# Altium schematic sheet TOP.SchDoc
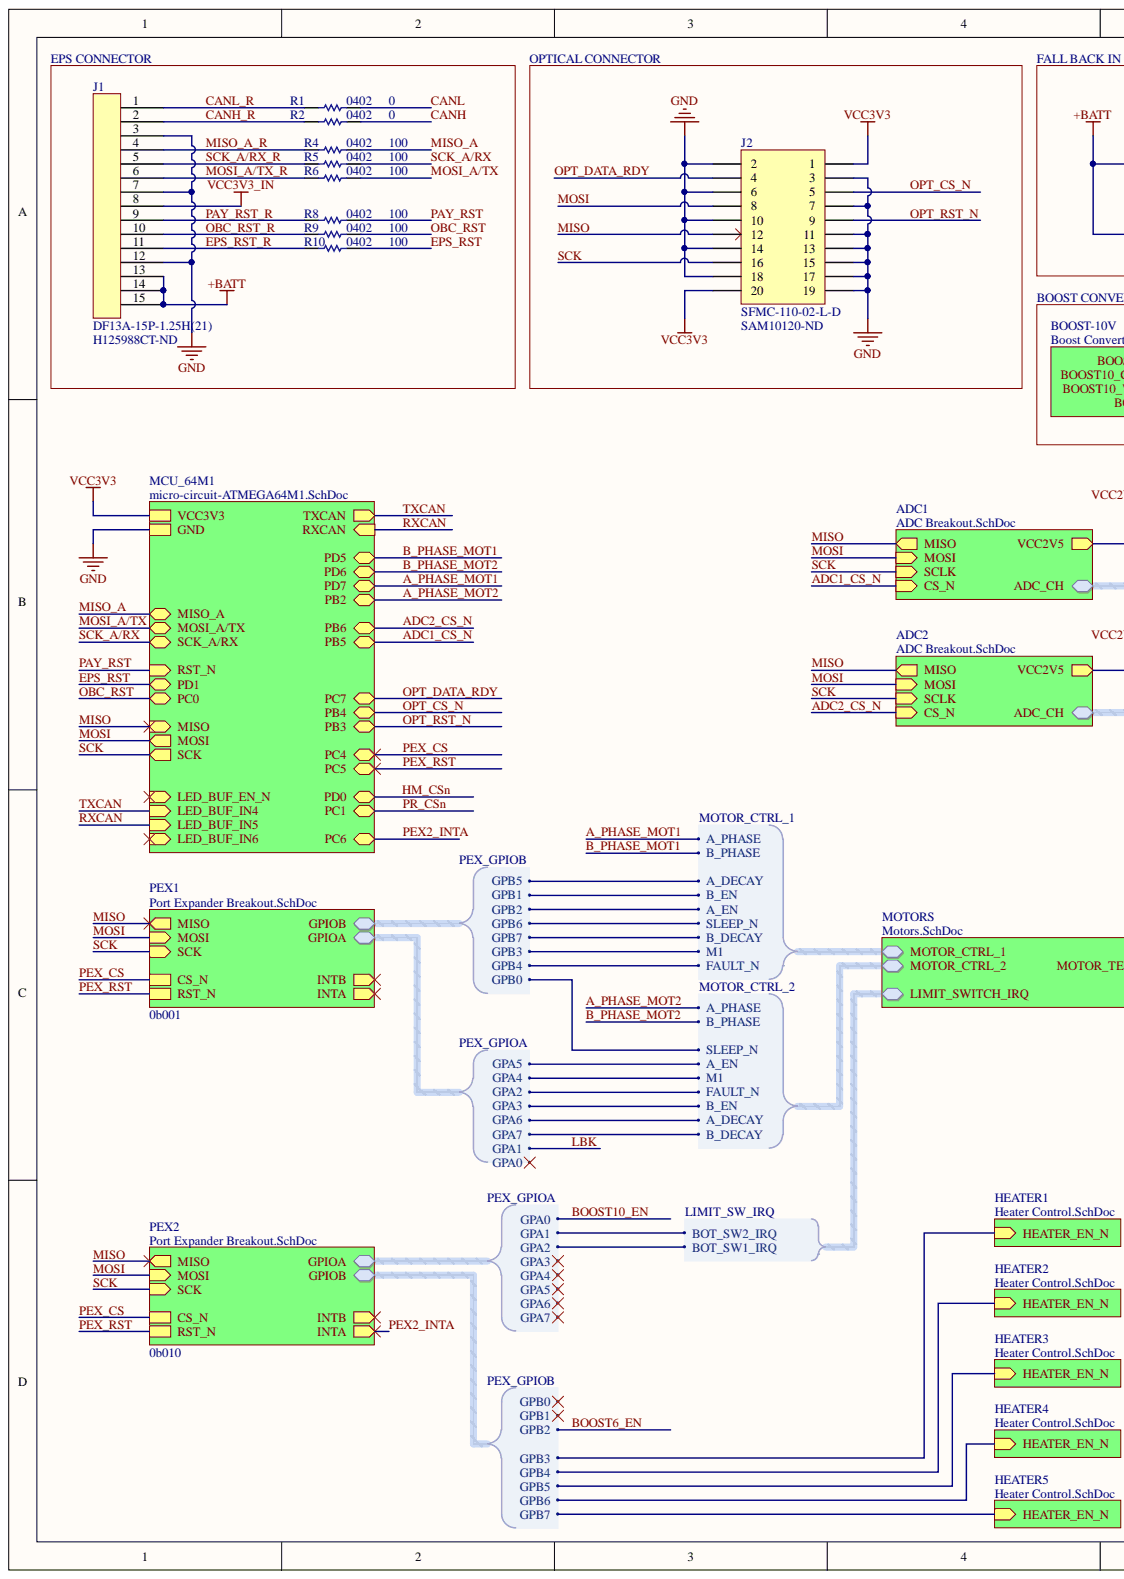
[diagram p0: TOP.SchDoc, left]
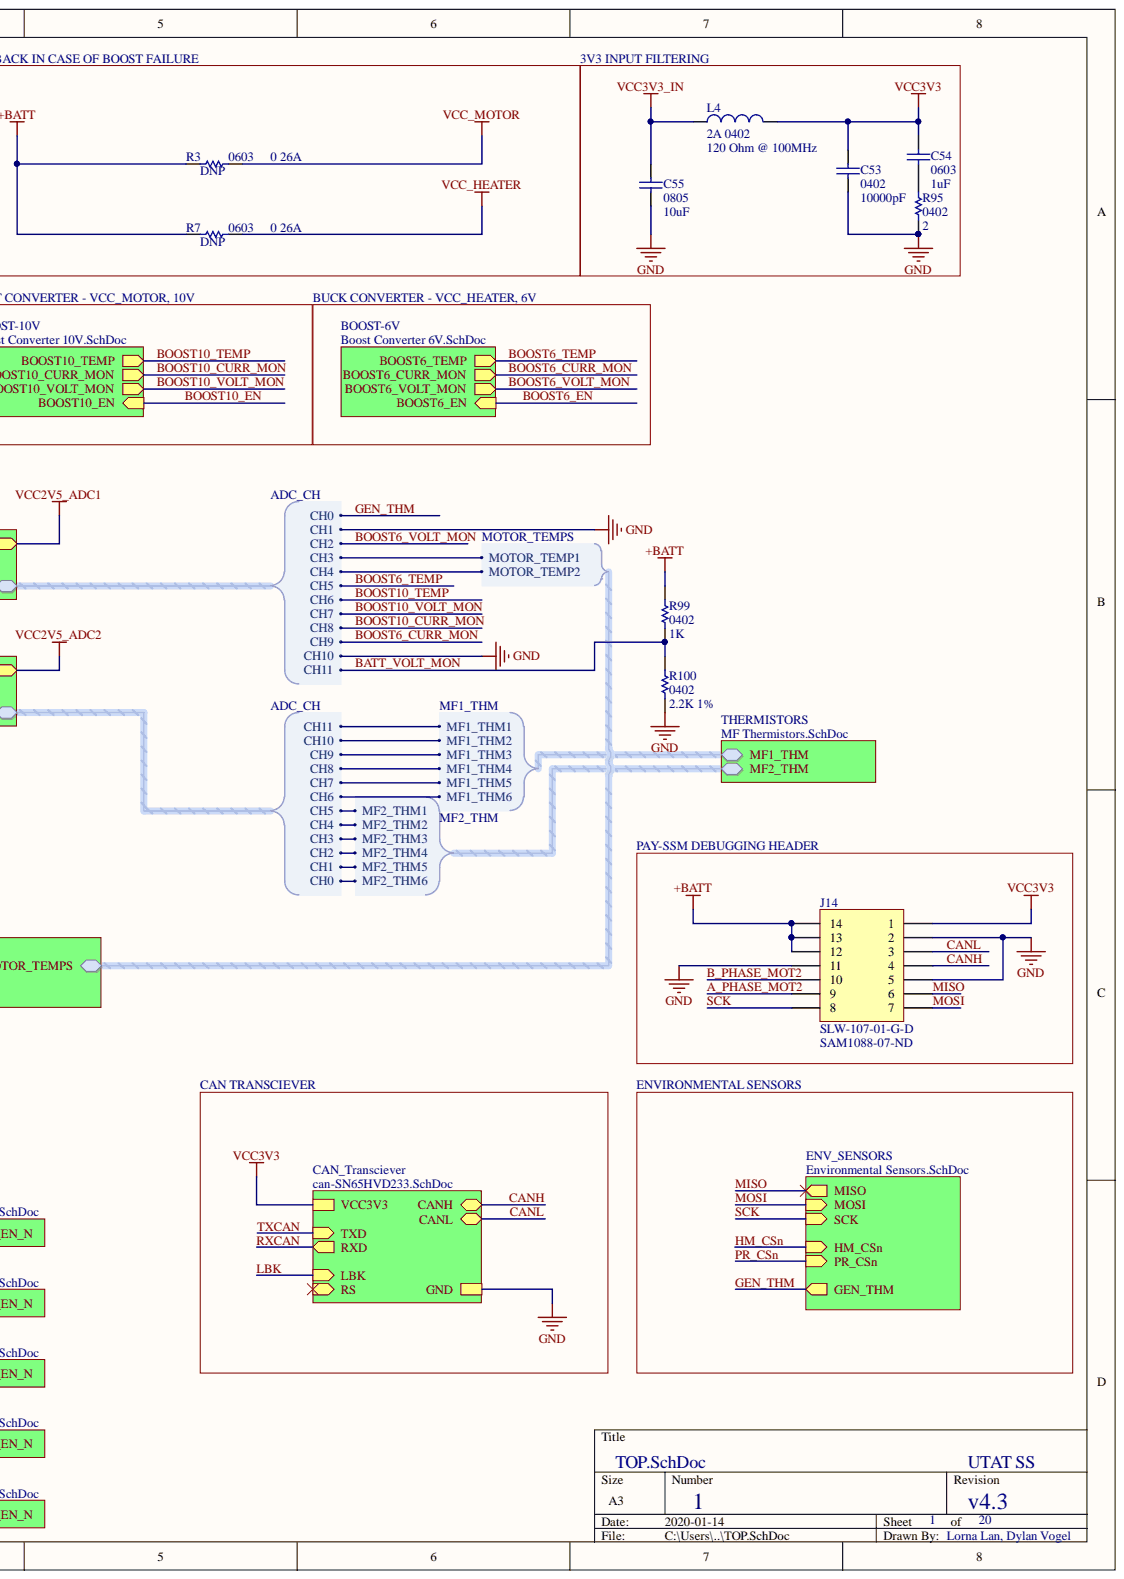
[diagram p1: TOP.SchDoc, right]

## Parts
C53  0402  value 10000pF  [CAP0402R]
C54  0603  value 1uF  Capacitance: 1uF  [CAP0603R]
C55  0805  value 10uF  Capacitance: 10uF  [CAP0805R]
J1  DF13A-15P-1.25H(21)  Supplier Part Number 1: H125988CT-ND  [DF13A-15P-1.25H(21)]
J2  SFMC-110-02-L-D  Supplier Part Number 1: SAM10120-ND  [TFM-110-02-L-D]
J14  SLW-107-01-G-D  Supplier Part Number 1: SAM1088-07-ND  [SLW-107-01-X-D]
L4  2A 0402  value 120 Ohm @ 100MHz  Case/Package: 0402  Max Current Rating: 2A  [RES0402R]
R1  0402  value 0  [RES0402R]
R2  0402  value 0  [RES0402R]
R3  0603  value 0 26A  [RES0603R]
R4  0402  value 100  [RES0402R]
R5  0402  value 100  [RES0402R]
R6  0402  value 100  [RES0402R]
R7  0603  value 0 26A  [RES0603R]
R8  0402  value 100  [RES0402R]
R9  0402  value 100  [RES0402R]
R10  0402  value 100  [RES0402R]
R95  0402  value 2  [RES0402R]
R99  0402  value 1K  [RES0402R]
R100  0402  value 2.2K 1%  [RES0402R]

## Nets
+BATT: J1.13 J1.14 J1.15 J14.2 J14.4 J14.6 R3.1 R7.1 R99.2
GND: C53.1 C55.1 J1.3 J1.7 J1.12 J2.2 J2.3 J2.6 J2.7 J2.10 J2.11 J2.13 J2.14 J2.15 J2.17 J2.18 J2.19 J14.3 J14.8 J14.9 R95.1 R100.1
VCC3V3: C53.2 C54.2 J2.1 J2.20 J14.1 L4.2
VCC3V3_IN: C55.2 J1.8 L4.1
VCC_HEATER: R7.2
VCC_MOTOR: R3.2
A_PHASE_MOT2: J14.12
B_PHASE_MOT2: J14.10
BATT_VOLT_MON: R99.1 R100.2
CANH: J14.7 R2.2
CANH_R: J1.2 R2.1
CANL: J14.5 R1.2
CANL_R: J1.1 R1.1
EPS_RST: R10.2
EPS_RST_R: J1.11 R10.1
MISO: J2.12 J14.11
MISO_A: R4.2
MISO_A_R: J1.4 R4.1
MOSI: J2.8 J14.13
MOSI_A/TX: R6.2
MOSI_A/TX_R: J1.6 R6.1
OBC_RST: R9.2
OBC_RST_R: J1.10 R9.1
OPT_CS_N: J2.5
OPT_DATA_RDY: J2.4
OPT_RST_N: J2.9
PAY_RST: R8.2
PAY_RST_R: J1.9 R8.1
SCK: J2.16 J14.14
SCK_A/RX: R5.2
SCK_A/RX_R: J1.5 R5.1
NetC54_1: C54.1 R95.2
A_PHASE_MOT1:
ADC1_CS_N:
ADC2_CS_N:
B_PHASE_MOT1:
BOOST6_CURR_MON:
BOOST6_EN:
BOOST6_TEMP:
BOOST6_VOLT_MON:
BOOST10_CURR_MON:
BOOST10_EN:
BOOST10_TEMP:
BOOST10_VOLT_MON:
GEN_THM:
HM_CSn:
LBK:
N00208:
N00209:
N00210:
N00211:
N00212:
N00213:
N00214:
N00215:
N00216:
N00217:
N00218:
N00219:
N00220:
N00221:
N00222:
N00223:
N00224:
N00225:
N00226:
N00227:
N00228:
N00229:
N00230:
N00231:
N00232:
N00233:
N00234:
N00235:
N00236:
N00237:
N00238:
N00239:
N00240:
N00241:
N00242:
N00243:
N00244:
N00245:
N00246:
N00247:
N00248:
N00249:
N00250:
N00251:
N00252:
N00253:
N00254:
N00255:
N00256:
PEX2_INTA:
PEX_CS:
PEX_RST:
PR_CSn:
RXCAN:
TXCAN:
VCC2V5_ADC1:
VCC2V5_ADC2:

## Library data of the parts
C53 = Cap: Capacitor; Component Kind: Standard; LatestRevisionDate: 17-Jul-2002; LatestRevisionNote: Re-released for DXP Platform.; Library Name: Miscellaneous Devices.IntLib; PackageDescription: Radial Cap, Thru-Hole; 2 Leads; 0.3 in Pin Spacing; PackageReference: RAD-0.3; Published: 8-Jun-2000; Signal Integrity: Cap; Simulation: CAP; Publisher: Altium Limited
C54 = CAP0603: 0603 capacitor; Manufacturer: KEMET; Manufacturer Part Number: C0603C105K4RACAUTO; Tolerance: 10%; Voltage Rating: 16V; Case/Package: 0603; Case Code (Imperial): 0603; Case Code (Metric): 1608; Component Kind: Standard; Max Operating Temperature: 125°C; Min Operating Temperature: -55°C; Mount: Surface Mount; Packaging: Cut Tape; Pricing 1: 1=0.17, 10=0.12, 100=0.0564, 500=0.03952, 1000=0.03273 (USD); Ratings: AEC-Q200; Signal Integrity: Cap; Simulation: CAP; Stock 1: 376276; Supplier 1: Digi-Key; Supplier 2: Digi-Key; Supplier Part Number 1: 399-17704-1-ND; Supplier Part Number 2: 587-3467-1-ND; Library Name: heron-general.IntLib
C55 = CAP0805: 0805 capacitor; Manufacturer: Murata; Manufacturer Part Number: GCM21BR70J106KE22K; Dielectric: X7R; Tolerance: 10%; Voltage Rating (DC): 6.3V; Case/Package: 0805; Component Kind: Standard; Depth: 1.25mm; Lead Free: Lead Free; Length: 2mm; Max Operating Temperature: 125°C; Min Operating Temperature: -55°C; Packaging: Tape and Reel; Pricing 1: 1=0.42, 10=0.29, 100=0.1742, 500=0.13064, 1000=0.11031, 2500=0.10451, 5000=0.0987 (USD); Signal Integrity: Cap; Simulation: CAP; Stock 1: 214938; Supplier 1: Digi-Key; Supplier Part Number 1: 490-14361-1-ND; Library Name: heron-general.IntLib
J1 = DF13A-15P-1.25H(21): 15 pos DF13 right angle surface mount connector; Component Kind: Standard; Supplier 1: Digi-Key; Library Name: heron-general.IntLib
J2 = SFMC-110-02-L-D: 20 pos 50mil pitch low profile SMD connector; Component Kind: Standard; Library Name: TFM-110-02-L-D.Schlib; Supplier 1: Digi-Key
J14 = SLW-107-01-G-D: 14 pos 100 mil pitch low profile header; Component Kind: Standard; Supplier 1: Digi-Key; Library Name: heron-general.IntLib
L4 = Inductor: Manufacturer: Murata; Manufacturer Part Number: BLM15PX121SZ1D; Code_IEC: 1005; DC Resistance (DCR): 55mR; Number of Lines: 1; Case Code (Imperial): 0402; Case Code (Metric): 1005; Code_JEITA: 0402; Component Kind: Standard; Description: Inductor; LatestRevisionDate: 29-May-2009; LatestRevisionNote: IPC-7351 Footprint Added.; Library Name: Miscellaneous Devices.IntLib; Max Operating Temperature: 125°C; Min Operating Temperature: -55°C; Mount: Surface Mount; PackageDescription: Chip Inductor; PackageReference: 0402-A; Packaging: Cut Tape; Pricing 1: 1=0.15, 10=0.125, 25=0.0972, 50=0.0804, 100=0.0693, 250=0.05544, 500=0.0499, 1000=0.04158, 5000=0.03881 (USD); Published: 8-Jun-2000; Ratings: AEC-Q200; Signal Integrity: Inductor; Simulation: INDUCTOR; Stock 1: 18936; Supplier 1: Digi-Key; Supplier Part Number 1: 490-16505-1-ND; Publisher: Altium Limited
R1 R2 = RES0402: 0402 resistor; Manufacturer: Panasonic; Manufacturer Part Number: ERJ-2GE0R00X; Max Power Dissipation: 100mW; Resistance: 0mR; Temperature Coefficient: 600ppm/°C; Tolerance: 5%; Voltage Rating: 50V; Case/Package: 0402; Case Code (Imperial): 0402; Case Code (Metric): 1005; Component Kind: Standard; ComponentLink1Description: http://industrial.panasonic.com/www-data/pdf/AOA0000/AOA0000CE1.pdf?src-supplier=Avnet; ComponentLink1URL: http://industrial.panasonic.com/www-data/pdf/AOA0000/AOA0000CE1.pdf?src-supplier=Avnet; ComponentLink2Description: http://www.alliedelec.com/m/d/51cd0790071e1666bdb9d00c57fcf960.pdf?src-supplier=Allied+Electronics; ComponentLink2URL: http://www.alliedelec.com/m/d/51cd0790071e1666bdb9d00c57fcf960.pdf?src-supplier=Allied+Electronics; ComponentLink3Description: http://industrial.panasonic.com/www-data/pdf/AOA0000/AOA0000CE1.pdf?src-supplier=Avnet+Europe; ComponentLink3URL: http://industrial.panasonic.com/www-data/pdf/AOA0000/AOA0000CE1.pdf?src-supplier=Avnet+Europe; ComponentLink4Description: http://www.farnell.com/datasheets/2059623.pdf?src-supplier=Farnell; ComponentLink4URL: http://www.farnell.com/datasheets/2059623.pdf?src-supplier=Farnell; ComponentLink5Description: http://www.farnell.com/datasheets/2059623.pdf?src-supplier=Element14; ComponentLink5URL: http://www.farnell.com/datasheets/2059623.pdf?src-supplier=Element14; ComponentLink6Description: http://www.farnell.com/datasheets/1678796.pdf?src-supplier=Element14; ComponentLink6URL: http://www.farnell.com/datasheets/1678796.pdf?src-supplier=Element14; Composition: Thick Film; Contact Plating: Tin; Depth: 0.5mm; Features: Automotive AEC-Q200; Height: 0.35mm; Lead Free: Lead Free; Length: 1mm; Max Operating Temperature: 155°C; Military Standard: Not; Min Operating Temperature: -55°C; Mount: Surface Mount; Number of Terminations: 2; Package Quantity: 1; Packaging: Tape and Reel; Power Rating: 100mW; Pricing 1: 1=0.1, 10=0.03, 25=0.0216, 50=0.0164, 100=0.0121, 250=0.00924, 500=0.0074, 1000=0.00545, 5000=0.00391 (USD); Radiation Hardening: No; REACH SVHC: No SVHC; Signal Integrity: Res; Simulation: RESISTOR; Stock 1: 47740000; Supplier 1: Digi-Key; Supplier Part Number 1: P0.0JCT-ND; Termination: SMD/SMT; Voltage Rating (DC): 50V; Width: 0.02inch; Library Name: heron-general.IntLib
R3 R7 = RES0603: 0603 resistor; Component Kind: Standard; Signal Integrity: Res; Simulation: RESISTOR; Supplier 1: Digi-Key; Supplier Part Number 1: 2019-TLRZ1JTTDCT-ND; Library Name: heron-general.IntLib
R4-R6 R8-R10 R99 R100 = RES0402: 0402 resistor; Component Kind: Standard; Signal Integrity: Res; Simulation: RESISTOR
  R99: Library Name: RES0402.SchLib; Supplier 1: Digi-Key; Supplier Part Number 1: YAG1234CT-ND
  R4-R6 R8-R10 R100: Library Name: heron-general.IntLib
R95 = Res1: Resistor; Component Kind: Standard; LatestRevisionDate: 17-Jul-2002; LatestRevisionNote: Re-released for DXP Platform.; Library Name: Miscellaneous Devices.IntLib; PackageDescription: Axial Device, Thru-Hole; 2 Leads; 0.3 in Pin Spacing; PackageReference: AXIAL-0.3; Published: 8-Jun-2000; Signal Integrity: Res; Simulation: RESISTOR; Supplier 1: Digi-Key; Supplier Part Number 1: YAG3472CT-ND; Publisher: Altium Limited
